# Altium schematic sheet PP.SchDoc(PP)
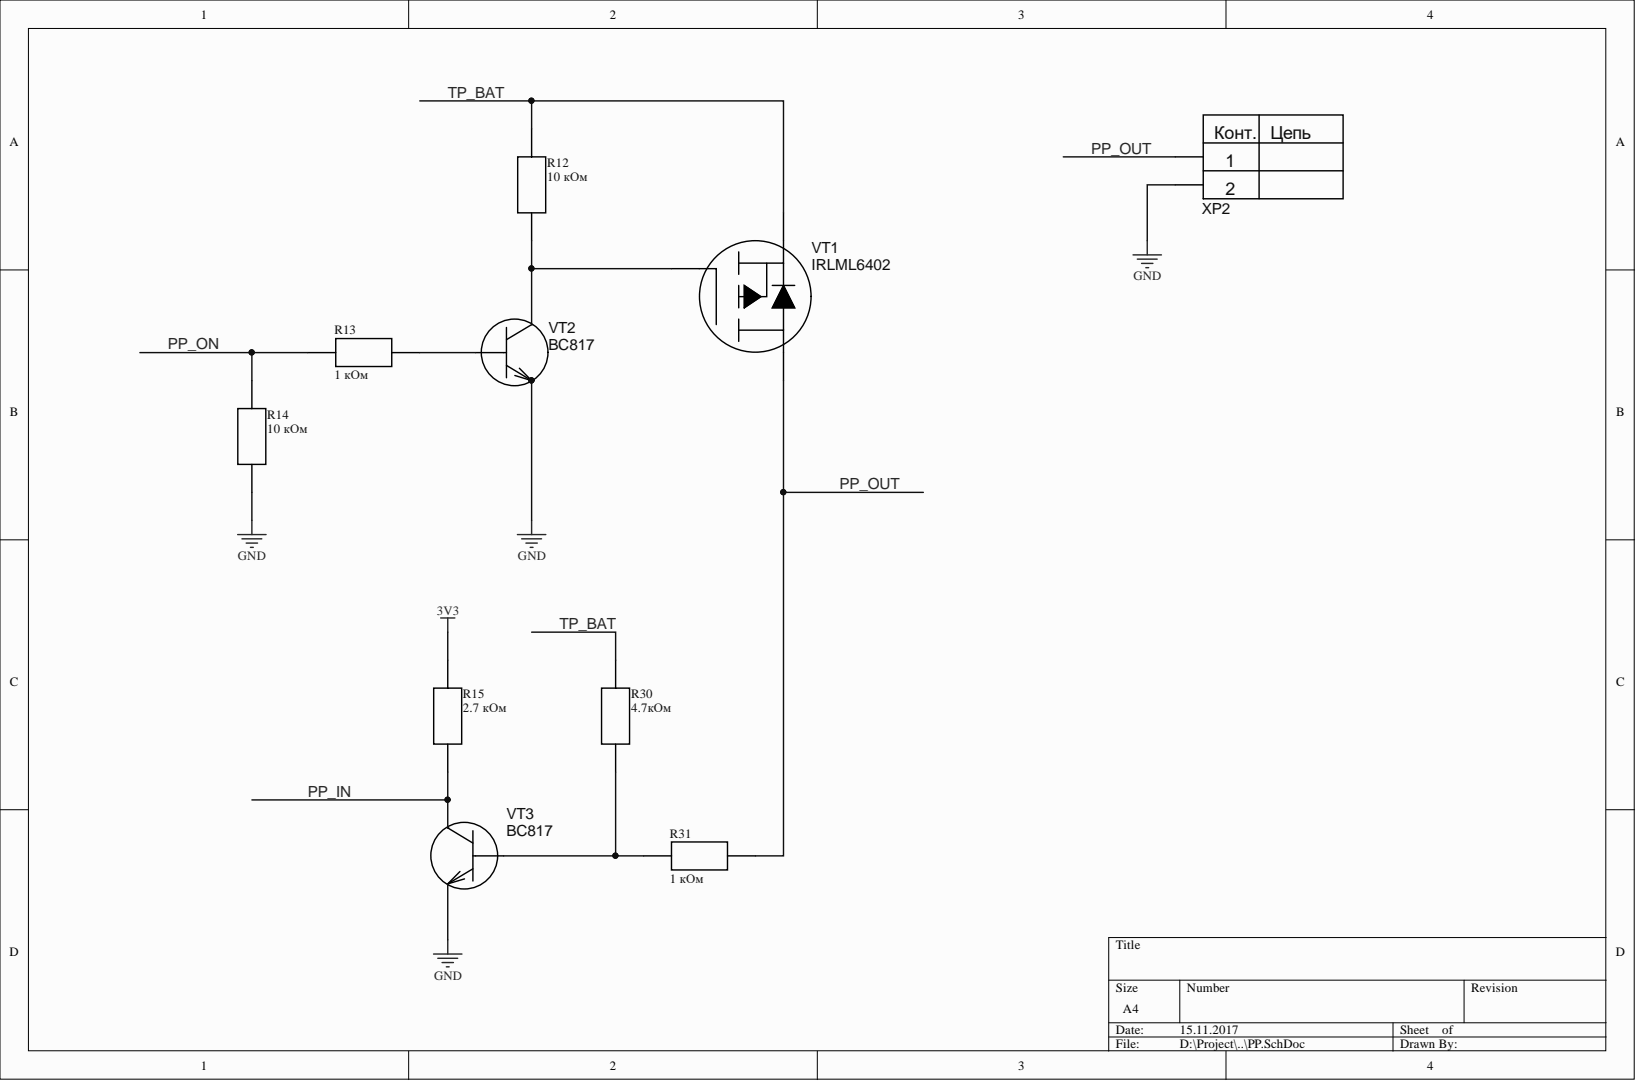
[diagram p0: PP.SchDoc]

## Parts
R12  10 кОм  [RES 0805]
R13  1 кОм  [RES 0805]
R14  10 кОм  [RES 0805]
R15  2.7 кОм  [RES 0805]
R30  4.7кОм  [RES 0805]
R31  1 кОм  [RES 0805]
VT1  IRLML6402  [SOT-23]
VT2  BC817  [SOT-23]
VT3  BC817  [SOT-23]
XP2  PLS-2  [PLS-2]  (value not drawn)

## Nets
3V3: R15.1
GND: R14.2 VT2.2 VT3.2 XP2.2
PP_IN: R15.2 VT3.3
PP_ON: R13.2 R14.1
PP_OUT: R31.2 VT1.3 XP2.1
TP_BAT: R12.1 R30.1 VT1.2
NetR12_2: R12.2 VT1.1 VT2.3
NetR13_1: R13.1 VT2.1
NetR30_2: R30.2 R31.1 VT3.1

## Library data of the parts
R12-R15 R30 R31 = RES 0805: Library Name: my_lib.SchLib; Component Kind: Standard
VT2 VT3 = BC817: NPN general-purpose transistor; Manufacturer: NXP; Type: Транзистор биполярный; Structure: n-p-n; Specitication: ЭКБ ИП; Power dissitipation: 0,25 Вт; Max emitter-base voltage: 5 В; Max collector peak current: 1 А; Max collector current: 0,5 А; Max collector-emitter voltage: 45 В; Max collector-base voltage: 50 В; hFE: 160 ... 600; fT: 100 МГц; Complement: BC807; Collector-emitter saturation voltage: 0,7 В; Collector-base сapacitance: 3 пФ; Base-emitter on voltage: 1,2 В; Temperature range: -65 ... 150 °C; Library Name: my_lib.SchLib; Component Kind: Standard
XP2 = PLS-2: PLS connector; Manufacturer: NONAME; Number of contacts: 2; Connector type: Вилка на плату; Type: Разъем штыревой; Library Name: my_lib.SchLib; Component Kind: Standard
VT1 = IRLML6402: Library Name: my_lib.SchLib; Component Kind: Standard
